# Altium schematic sheet C1804 FreqCounter - Cotrol Main (REV04).SchDoc
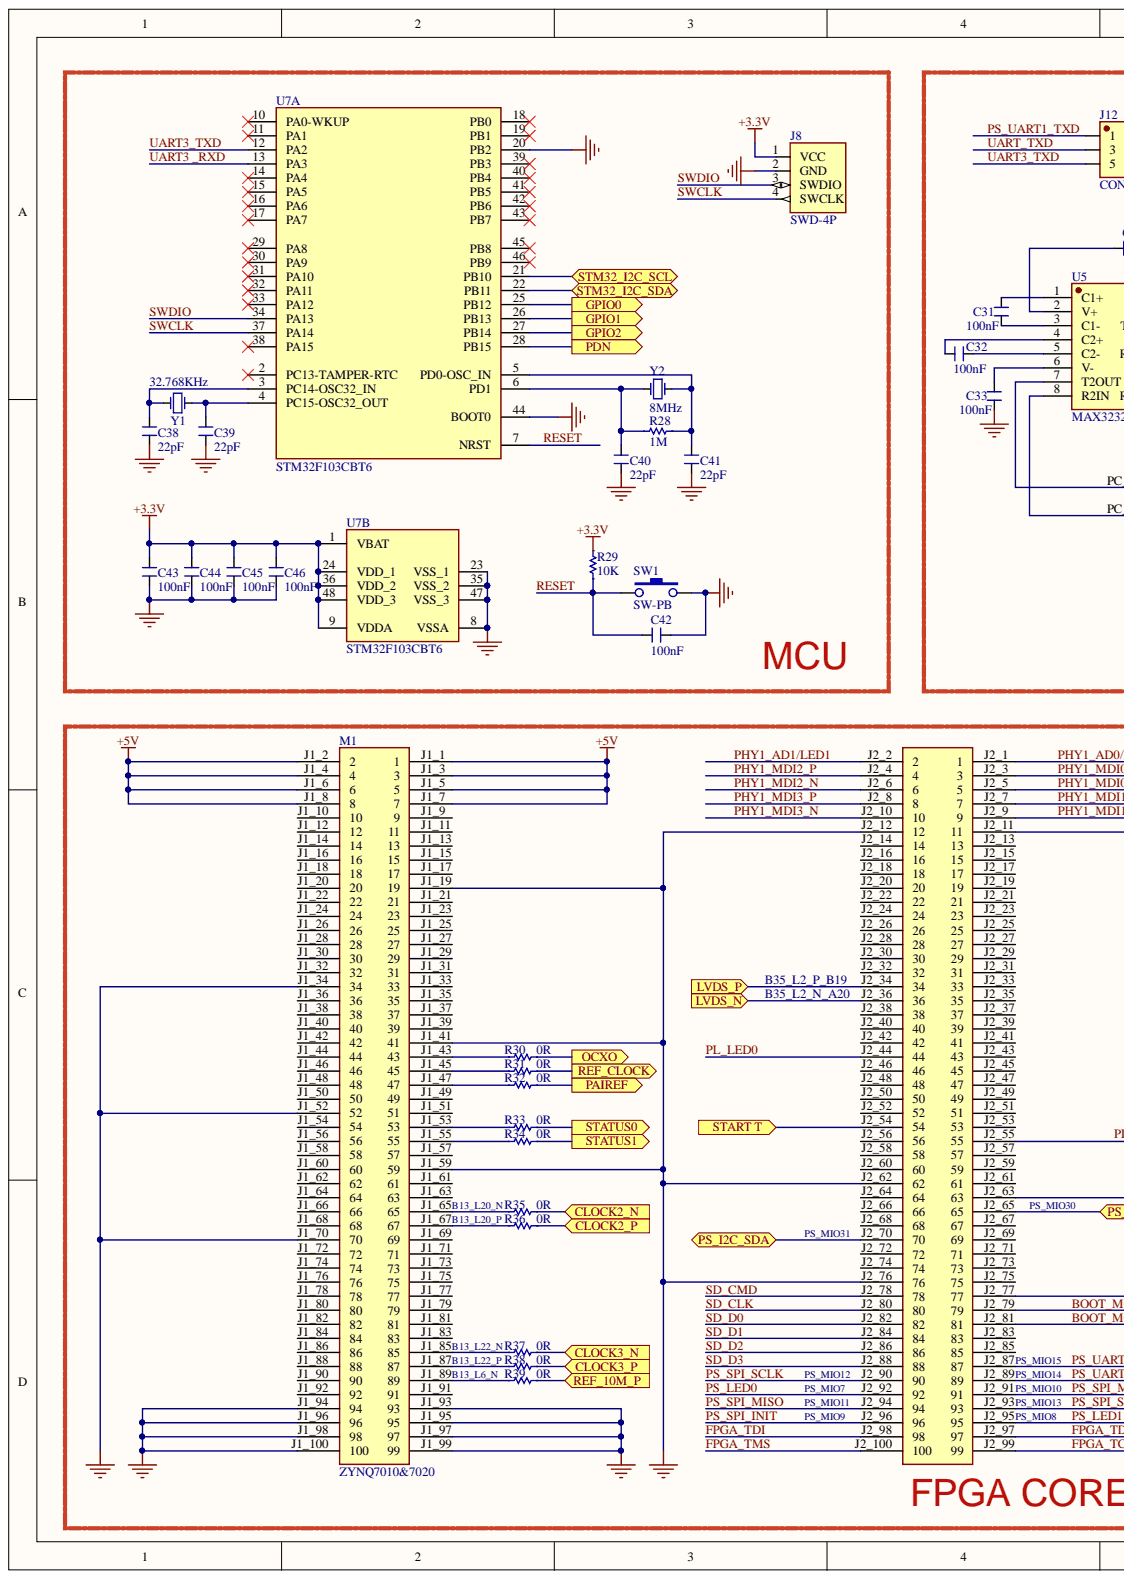
[diagram p0: C1804 FreqCounter - Cotrol Main (REV04).SchDoc, left]
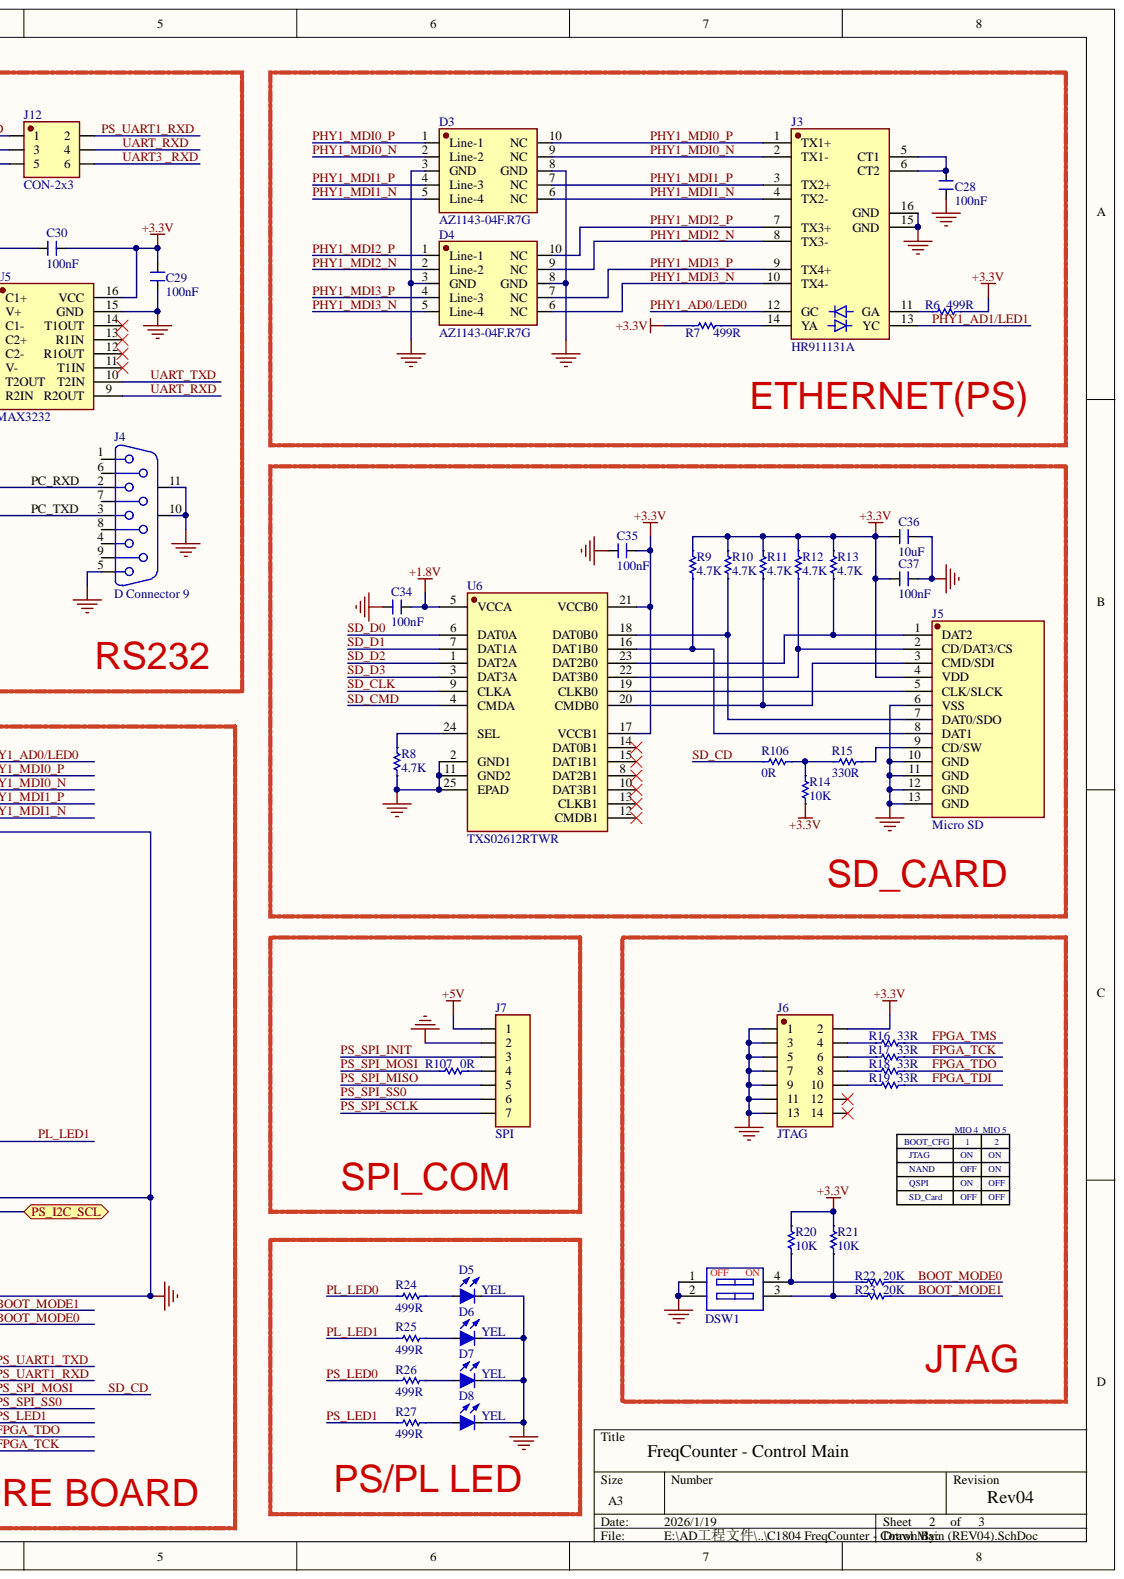
[diagram p1: C1804 FreqCounter - Cotrol Main (REV04).SchDoc, right]

## Parts
C28  100nF  [C-0603]
C29  100nF  [C-0603]
C30  100nF  [C-0603]
C31  100nF  [C-0603]
C32  100nF  [C-0603]
C33  100nF  [C-0603]
C34  100nF  [C-0603]
C35  100nF  [C-0603]
C36  10uF  [C-0603]
C37  100nF  [C-0603]
C38  22pF  [C-0603]
C39  22pF  [C-0603]
C40  22pF  [C-0603]
C41  22pF  [C-0603]
C42  100nF  [C-0603]
C43  100nF  [C-0603]
C44  100nF  [C-0603]
C45  100nF  [C-0603]
C46  100nF  [C-0603]
D3  AZ1143-04F.R7G  [DFN2510-10]
D4  AZ1143-04F.R7G  [DFN2510-10]
D5  YEL  [LED-0805]
D6  YEL  [LED-0805]
D7  YEL  [LED-0805]
D8  YEL  [LED-0805]
DSW1  DSWB02  [DSWB02 (P=2.54mm)]  (value not drawn)
J3  HR911131A  [HR911131A]
J4  D Connector 9  [DB9-Horizontal]
J5  Micro SD  [MICRO SD]
J6  JTAG  [DC3-14P (P=2.54MM)]
J7  SPI  [XH2.54-7P]
J8  SWD-4P  [SIP-4]
J12  CON-2x3  [DIP-2x3 (P=2.54MM)]
M1  ZYNQ7010&7020  [ZYNQ7010&7020_CB]
R6  499R  [R-0603]
R7  499R  [R-0603]
R8  4.7K  [R-0603]
R9  4.7K  [R-0603]
R10  4.7K  [R-0603]
R11  4.7K  [R-0603]
R12  4.7K  [R-0603]
R13  4.7K  [R-0603]
R14  10K  [R-0603]
R15  330R  [R-0603]
R16  33R  [R-0603]
R17  33R  [R-0603]
R18  33R  [R-0603]
R19  33R  [R-0603]
R20  10K  [R-0603]
R21  10K  [R-0603]
R22  20K  [R-0603]
R23  20K  [R-0603]
R24  499R  [R-0603]
R25  499R  [R-0603]
R26  499R  [R-0603]
R27  499R  [R-0603]
R28  1M  [R-0603]
R29  10K  [R-0603]
R30  0R  [R-0603]
R31  0R  [R-0603]
R32  0R  [R-0603]
R33  0R  [R-0603]
R34  0R  [R-0603]
R35  0R  [R-0603]
R36  0R  [R-0603]
R37  0R  [R-0603]
R38  0R  [R-0603]
R39  0R  [R-0603]
R106  0R  [R-0603]
R107  0R  [R-0603]
SW1  SW-PB  [TSW-SMD (3*6*2.5)]
U5  MAX3232  [SOIC-16 (BODY=3.9MM)]
U6  TXS02612RTWR  [WQFN-24 (4*4,P=0.5MM)]
U7  STM32F103CBT6  [LQFP-48]  (parts A,B)
Y1  32.768KHz  [XTAL-3215]
Y2  8MHz  [XTAL-HC49S]

## Ports
CLOCK2_N, CLOCK2_P, CLOCK3_N, CLOCK3_P, GPIO0, GPIO1, GPIO2, LVDS_N, LVDS_P, OCXO, PAIREF, PDN, PS_I2C_SCL, PS_I2C_SDA, REF_10M_P, REF_CLOCK, START T, STATUS0, STATUS1, STM32_I2C_SCL, STM32_I2C_SDA

## Nets
+1.8V: C34.2 U6.5(VCCA)
+3.3V: C29.2 C30.2 C35.2 C36.1 C37.1 C43.2 C44.2 C45.2 C46.2 J5.4(VDD) J6.2 J8.1(VCC) R6.1 R7.2 R9.2 R10.2 R11.2 R12.2 R13.2 R14.1 R20.2 R21.2 R29.2 U5.16(VCC) U6.17(VCCB1) U6.21(VCCB0) U7.1(VBAT) U7.9(VDDA) U7.24(VDD_1) U7.36(VDD_2) U7.48(VDD_3)
+5V: J7.1 M1.J1_1 M1.J1_2 M1.J1_3 M1.J1_4 M1.J1_5 M1.J1_6 M1.J1_7 M1.J1_8
GND: C28.1 C29.1 C33.1 C34.1 C35.1 C36.2 C37.2 C38.1 C39.1 C40.2 C41.2 C42.1 C43.1 C44.1 C45.1 C46.1 D3.3(GND) D3.8(GND) D4.3(GND) D4.8(GND) D5.K D6.K D7.K D8.K DSW1.1 DSW1.2 J3.15(GND) J3.16(GND) J4.5 J4.10 J4.11 J5.6(VSS) J5.10(GND) J5.11(GND) J5.12(GND) J5.13(GND) J6.1 J6.3 J6.5 J6.7 J6.9 J6.11 J6.13 J7.2 J8.2(GND) M1.J1_19 M1.J1_34 M1.J1_41 M1.J1_52 M1.J1_59 M1.J1_70 M1.J1_93 M1.J1_94 M1.J1_95 M1.J1_96 M1.J1_97 M1.J1_98 M1.J1_99 M1.J1_100 M1.J2_11 M1.J2_12 M1.J2_62 M1.J2_63 M1.J2_76 M1.J2_77 R8.1 SW1.2 U5.15(GND) U6.2(GND1) U6.11(GND2) U6.25(EPAD) U7.8(VSSA) U7.20(PB2) U7.23(VSS_1) U7.35(VSS_2) U7.44(BOOT0) U7.47(VSS_3)
BOOT_MODE0: M1.J2_81 R22.1
BOOT_MODE1: M1.J2_79 R23.1
CLOCK2_N: R35.2 ; port CLOCK2_N
CLOCK2_P: R36.2 ; port CLOCK2_P
CLOCK3_N: R37.2 ; port CLOCK3_N
CLOCK3_P: R38.2 ; port CLOCK3_P
FPGA_TCK: M1.J2_99 R17.1
FPGA_TDI: M1.J2_98 R19.1
FPGA_TDO: M1.J2_97 R18.1
FPGA_TMS: M1.J2_100 R16.1
GPIO0: U7.25(PB12) ; port GPIO0
GPIO1: U7.26(PB13) ; port GPIO1
GPIO2: U7.27(PB14) ; port GPIO2
LVDS_N: M1.J2_36 ; port LVDS_N
LVDS_P: M1.J2_34 ; port LVDS_P
OCXO: R30.2 ; port OCXO
PAIREF: R32.2 ; port PAIREF
PDN: U7.28(PB15) ; port PDN
PHY1_AD0/LED0: J3.12(GC) M1.J2_1
PHY1_AD1/LED1: J3.13(YC) M1.J2_2
PHY1_MDI0_N: D3.2(Line-2) D3.9(NC) J3.2(TX1-) M1.J2_5
PHY1_MDI0_P: D3.1(Line-1) D3.10(NC) J3.1(TX1+) M1.J2_3
PHY1_MDI1_N: D3.5(Line-4) D3.6(NC) J3.4(TX2-) M1.J2_9
PHY1_MDI1_P: D3.4(Line-3) D3.7(NC) J3.3(TX2+) M1.J2_7
PHY1_MDI2_N: D4.2(Line-2) D4.9(NC) J3.8(TX3-) M1.J2_6
PHY1_MDI2_P: D4.1(Line-1) D4.10(NC) J3.7(TX3+) M1.J2_4
PHY1_MDI3_N: D4.5(Line-4) D4.6(NC) J3.10(TX4-) M1.J2_10
PHY1_MDI3_P: D4.4(Line-3) D4.7(NC) J3.9(TX4+) M1.J2_8
PL_LED0: M1.J2_44 R24.2
PL_LED1: M1.J2_55 R25.2
PS_I2C_SCL: M1.J2_65 ; port PS_I2C_SCL
PS_I2C_SDA: M1.J2_70 ; port PS_I2C_SDA
PS_LED0: M1.J2_92 R26.2
PS_LED1: M1.J2_95 R27.2
PS_SPI_INIT: J7.3 M1.J2_96
PS_SPI_MISO: J7.5 M1.J2_94
PS_SPI_MOSI: M1.J2_91 R106.2 R107.2
PS_SPI_SCLK: J7.7 M1.J2_90
PS_SPI_SS0: J7.6 M1.J2_93
PS_UART1_RXD: J12.2 M1.J2_89
PS_UART1_TXD: J12.1 M1.J2_87
REF_10M_P: R39.2 ; port REF_10M_P
REF_CLOCK: R31.2 ; port REF_CLOCK
RESET: C42.2 R29.1 SW1.1 U7.7(NRST)
SD_CLK: M1.J2_80 U6.9(CLKA)
SD_CMD: M1.J2_78 U6.4(CMDA)
SD_D0: M1.J2_82 U6.6(DAT0A)
SD_D1: M1.J2_84 U6.7(DAT1A)
SD_D2: M1.J2_86 U6.1(DAT2A)
SD_D3: M1.J2_88 U6.3(DAT3A)
START T: M1.J2_54 ; port START T
STATUS0: R33.2 ; port STATUS0
STATUS1: R34.2 ; port STATUS1
STM32_I2C_SCL: U7.21(PB10) ; port STM32_I2C_SCL
STM32_I2C_SDA: U7.22(PB11) ; port STM32_I2C_SDA
SWCLK: J8.4(SWCLK) U7.37(PA14)
SWDIO: J8.3(SWDIO) U7.34(PA13)
UART3 _RXD: J12.6 U7.13(PA3)
UART3_TXD: J12.5 U7.12(PA2)
UART_RXD: J12.4 U5.9(R2OUT)
UART_TXD: J12.3 U5.10(T2IN)
NetC28_2: C28.2 J3.5(CT1) J3.6(CT2)
NetC30_1: C30.1 U5.2(V+)
NetC31_1: C31.1 U5.3(C1-)
NetC31_2: C31.2 U5.1(C1+)
NetC32_1: C32.1 U5.4(C2+)
NetC32_2: C32.2 U5.5(C2-)
NetC33_2: C33.2 U5.6(V-)
NetC38_2: C38.2 U7.3(PC14-OSC32_IN) Y1.2
NetC39_2: C39.2 U7.4(PC15-OSC32_OUT) Y1.1
NetC40_1: C40.1 R28.1 U7.6(PD1) Y2.1
NetC41_1: C41.1 R28.2 U7.5(PD0-OSC_IN) Y2.2
NetD5_A: D5.A R24.1
NetD6_A: D6.A R25.1
NetD7_A: D7.A R26.1
NetD8_A: D8.A R27.1
NetDSW1_3: DSW1.3 R21.1 R23.2
NetDSW1_4: DSW1.4 R20.1 R22.2
NetJ3_11: J3.11(GA) R6.2
NetJ3_14: J3.14(YA) R7.1
NetJ4_2: J4.2 U5.7(T2OUT)
NetJ4_3: J4.3 U5.8(R2IN)
NetJ5_1: J5.1(DAT2) R13.1 U6.23(DAT2B0)
NetJ5_2: J5.2(CD/DAT3/CS) R12.1 U6.22(DAT3B0)
NetJ5_3: J5.3(CMD/SDI) R11.1 U6.20(CMDB0)
NetJ5_5: J5.5(CLK/SLCK) U6.19(CLKB0)
NetJ5_7: J5.7(DAT0/SDO) R10.1 U6.18(DAT0B0)
NetJ5_8: J5.8(DAT1) R9.1 U6.16(DAT1B0)
NetJ5_9: J5.9(CD/SW) R15.2
NetJ6_4: J6.4 R16.2
NetJ6_6: J6.6 R17.2
NetJ6_8: J6.8 R18.2
NetJ6_10: J6.10 R19.2
NetJ7_4: J7.4 R107.1
NetM1_J1_43: M1.J1_43 R30.1
NetM1_J1_45: M1.J1_45 R31.1
NetM1_J1_47: M1.J1_47 R32.1
NetM1_J1_53: M1.J1_53 R33.1
NetM1_J1_55: M1.J1_55 R34.1
NetM1_J1_65: M1.J1_65 R35.1
NetM1_J1_67: M1.J1_67 R36.1
NetM1_J1_85: M1.J1_85 R37.1
NetM1_J1_87: M1.J1_87 R38.1
NetM1_J1_89: M1.J1_89 R39.1
NetR8_2: R8.2 U6.24(SEL)
NetR14_2: R14.2 R15.1 R106.1

Not connected: J4 1 4 6 7 8 9; J6 12 14; M1 J1_9 J1_10 J1_11 J1_12 J1_13 J1_14 J1_15 J1_16 J1_17 J1_18 J1_20 J1_21 J1_22 J1_23 J1_24 J1_25 J1_26 J1_27 J1_28 J1_29 J1_30 J1_31 J1_32 J1_33 J1_35 J1_36 J1_37 J1_38 J1_39 J1_40 J1_42 J1_44 J1_46 J1_48 J1_49 J1_50 J1_51 J1_54 J1_56 J1_57 J1_58 J1_60 J1_61 J1_62 J1_63 J1_64 J1_66 J1_68 J1_69 J1_71 J1_72 J1_73 J1_74 J1_75 J1_76 J1_77 J1_78 J1_79 J1_80 J1_81 J1_82 J1_83 J1_84 J1_86 J1_88 J1_90 J1_91 J1_92 J2_13 J2_14 J2_15 J2_16 J2_17 J2_18 J2_19 J2_20 J2_21 J2_22 J2_23 J2_24 J2_25 J2_26 J2_27 J2_28 J2_29 J2_30 J2_31 J2_32 J2_33 J2_35 J2_37 J2_38 J2_39 J2_40 J2_41 J2_42 J2_43 J2_45 J2_46 J2_47 J2_48 J2_49 J2_50 J2_51 J2_52 J2_53 J2_56 J2_57 J2_58 J2_59 J2_60 J2_61 J2_64 J2_66 J2_67 J2_68 J2_69 J2_71 J2_72 J2_73 J2_74 J2_75 J2_83 J2_85; U5 11(T1IN) 12(R1OUT) 13(R1IN) 14(T1OUT); U6 8(DAT2B1) 10(DAT3B1) 12(CMDB1) 13(CLKB1) 14(DAT0B1) 15(DAT1B1); U7 2(PC13-TAMPER-RTC) 10(PA0-WKUP) 11(PA1) 14(PA4) 15(PA5) 16(PA6) 17(PA7) 18(PB0) 19(PB1) 29(PA8) 30(PA9) 31(PA10) 32(PA11) 33(PA12) 38(PA15) 39(PB3) 40(PB4) 41(PB5) 42(PB6) 43(PB7) 45(PB8) 46(PB9)

## Library data of the parts
C28-C46 = CAP: Component Kind: Standard; Library Name: HW SCH Lib.SCHLIB
D3 D4 = AZ1143-04F.R7G: TVS/ESD; Component Kind: Standard; Library Name: HW SCH Lib.SCHLIB
D5-D8 = Diode LED: Typical RED, GREEN, YELLOW, AMBER GaAs LED; Component Kind: Standard; LatestRevisionDate: 17-Jul-2002; LatestRevisionNote: Re-released for DXP Platform.; Library Name: HW SCH Lib.SCHLIB; PackageDocument: Feb-2002; PackageReference: 3.2X1.6X1.1; Published: 8-Jun-2000; Publisher: Altium Limited
DSW1 = DSWB02: Dip Switch; Component Kind: Standard; Library Name: HW SCH Lib.SCHLIB
J3 = HR911131A: LAN; Ethernet Connector; Component Kind: Standard; Library Name: HW SCH Lib.SCHLIB
J4 = D Connector 9: Receptacle Assembly, 9 Position, Right Angle; PackageDocument: O; Positions: 9; Component Kind: Standard; DatasheetDocument: 1-Sep-1999; Gender: Receptacle; LatestRevisionDate: 17-Jul-2002; LatestRevisionNote: Re-released for DXP Platform.; Library Name: HW SCH Lib.SCHLIB; Orientation: Right Angle; PackageReference: 788750; Published: 15-Jun-2001; Publisher: Altium Limited
J5 = Micro SD: Micro SD Card Slot ; TF Card Slot; Component Kind: Standard; Library Name: HW SCH Lib.SCHLIB
J6 = CON-2x7P: Component Kind: Standard; Library Name: HW SCH Lib.SCHLIB
J7 = CON7: Connector; Component Kind: Standard; Library Name: HW SCH Lib.SCHLIB
J12 = CON-2x3P: Component Kind: Standard; Library Name: HW SCH Lib.SCHLIB
M1 = ZYNQ7010&7020: ZYNQ7010&7020 Core Board; Component Kind: Standard; Library Name: HW SCH Lib.SCHLIB
R6-R39 R106 R107 = RES: : 10K; Component Kind: Standard; Library Name: HW SCH Lib.SCHLIB
SW1 = SW-PB: Touch Switch; push botton; Component Kind: Standard; Library Name: HW SCH Lib.SCHLIB
U5 = MAX232: Component Kind: Standard; Library Name: HW SCH Lib.SCHLIB
U7 = STM32F103CBT6: STM32 ARM-based 32-bit MCU Performance Line with 128 kB Flash, 20 kB Internal RAM, -40 to +85癈 Temperature, 48-Pin LQFP, Tray; Component Kind: Standard; ComponentLink1Description: Manufacturer URL; ComponentLink1URL: http://www.st.com/; ComponentLink2Description: STM32F103 Datasheet; ComponentLink2URL: http://www.st.com/internet/com/TECHNICAL_RESOURCES/TECHNICAL_LITERATURE/DATASHEET/CD00161566.pdf; ComponentLink3Description: STM32 Family Web Page; ComponentLink3URL: www.st.com/stm32; Library Name: HW SCH Lib.SCHLIB
Y1 Y2 = XTAL: Crystal Oscillator; Component Kind: Standard; LatestRevisionDate: 17-Jul-2002; LatestRevisionNote: Re-released for DXP Platform.; Library Name: HW SCH Lib.SCHLIB; PackageReference: R38; Published: 8-Jun-2000; Publisher: Altium Limited
J8 = SWD-4P: Component Kind: Standard; Library Name: HW SCH Lib.SCHLIB
U6 = TXS02612RTWR: Component Kind: Standard; Library Name: HW SCH Lib.SCHLIB
